# Altium schematic sheet ADC.SchDoc(ADC)
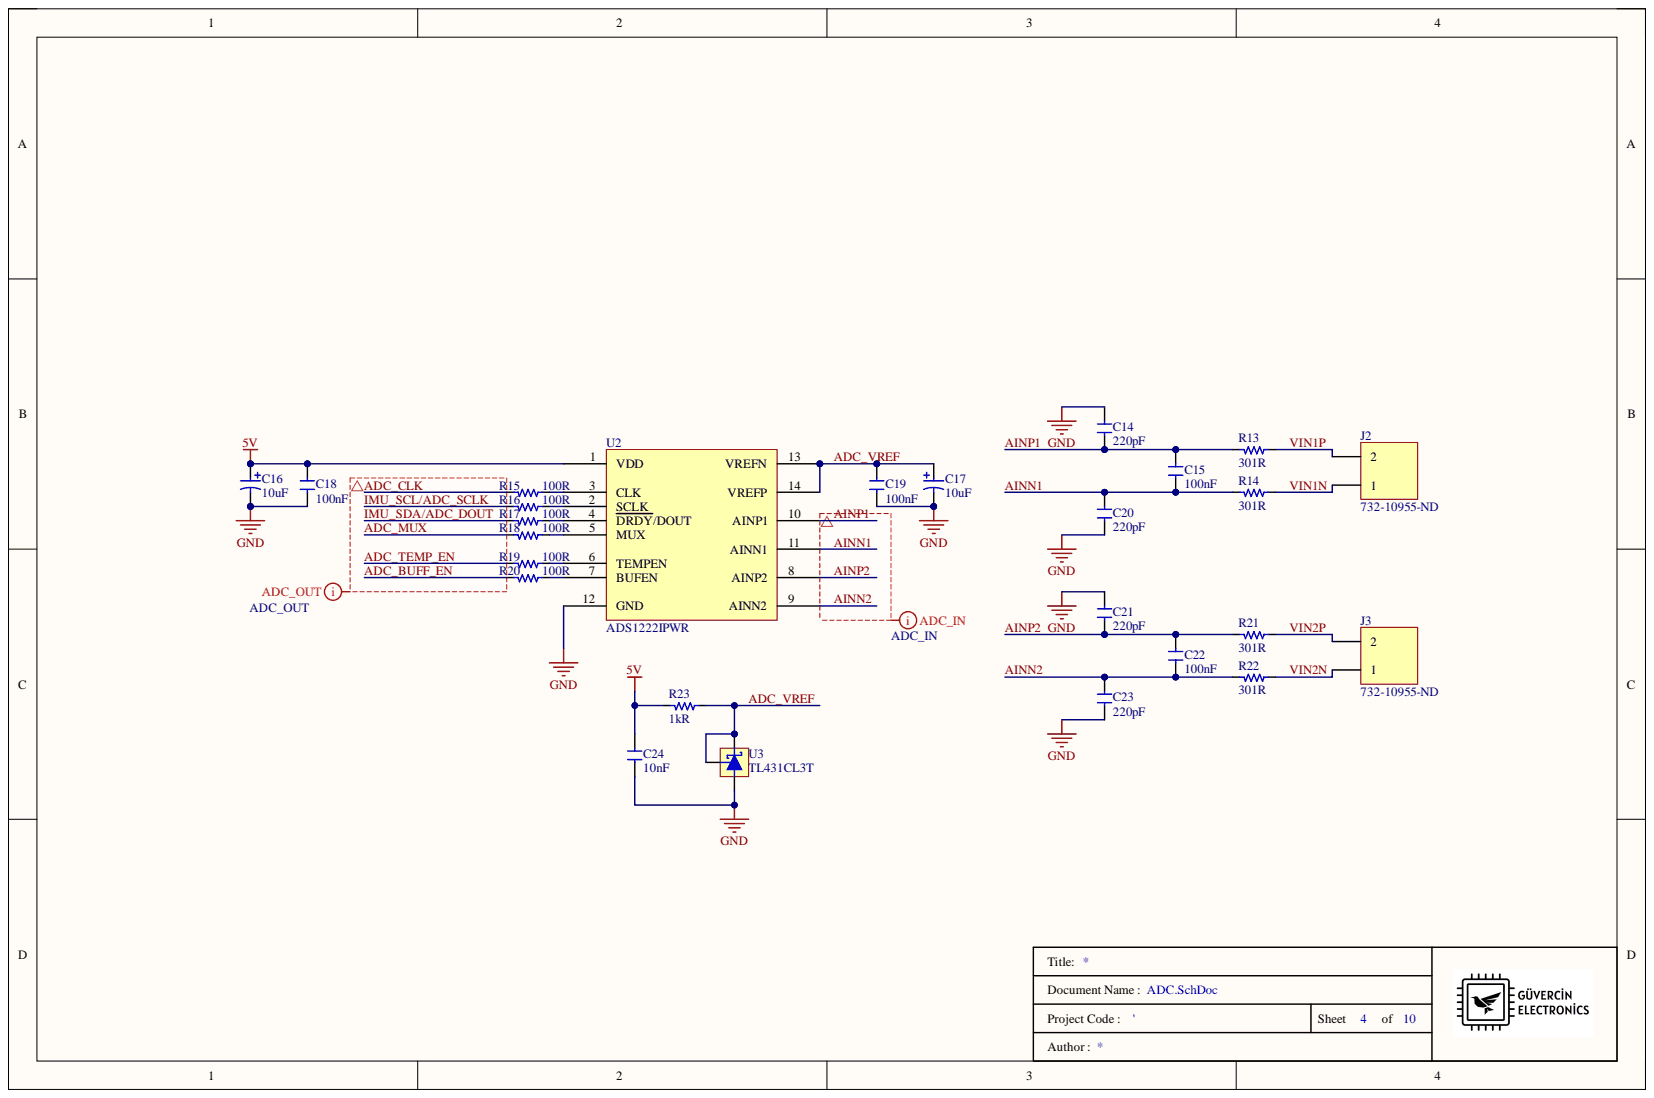
[diagram p0: ADC.SchDoc]

## Parts
C14  Capacitance: 220pF  [0805_CAP]  (hidden comment: CAP_220pF_50V_0805_C0G/NP0_CER)
C15  Capacitance: 100nF  [0805_CAP]  (hidden comment: CAP_0.1uF_50V_0805_X7R_CER)
C16  Capacitance: 10uF  [1206_TANT_CAP]  (hidden comment: CAP_10uF_10V_10%_1206_TANT)
C17  Capacitance: 10uF  [1206_TANT_CAP]  (hidden comment: CAP_10uF_10V_10%_1206_TANT)
C18  Capacitance: 100nF  [0805_CAP]  (hidden comment: CAP_0.1uF_50V_0805_X7R_CER)
C19  Capacitance: 100nF  [0805_CAP]  (hidden comment: CAP_0.1uF_50V_0805_X7R_CER)
C20  Capacitance: 220pF  [0805_CAP]  (hidden comment: CAP_220pF_50V_0805_C0G/NP0_CER)
C21  Capacitance: 220pF  [0805_CAP]  (hidden comment: CAP_220pF_50V_0805_C0G/NP0_CER)
C22  Capacitance: 100nF  [0805_CAP]  (hidden comment: CAP_0.1uF_50V_0805_X7R_CER)
C23  Capacitance: 220pF  [0805_CAP]  (hidden comment: CAP_220pF_50V_0805_C0G/NP0_CER)
C24  Capacitance: 10nF  [0805_CAP]  (hidden comment: CAP_10nF_%10_50V_0805_X7R_CER)
J2  Supplier Part Number 1: 732-10955-ND  [1x2_TERMINAL BLOCK]  (hidden comment: CONN_1X2_Terminal Block)
J3  Supplier Part Number 1: 732-10955-ND  [1x2_TERMINAL BLOCK]  (hidden comment: CONN_1X2_Terminal Block)
R13  Resistance: 301R  [0805_RES]  (hidden comment: RES_301 OHM_1%_0805_1/8W)
R14  Resistance: 301R  [0805_RES]  (hidden comment: RES_301 OHM_1%_0805_1/8W)
R15  Resistance: 100R  [0805_RES]  (hidden comment: RES_100 OHM_1%_0805_1/8W)
R16  Resistance: 100R  [0805_RES]  (hidden comment: RES_100 OHM_1%_0805_1/8W)
R17  Resistance: 100R  [0805_RES]  (hidden comment: RES_100 OHM_1%_0805_1/8W)
R18  Resistance: 100R  [0805_RES]  (hidden comment: RES_100 OHM_1%_0805_1/8W)
R19  Resistance: 100R  [0805_RES]  (hidden comment: RES_100 OHM_1%_0805_1/8W)
R20  Resistance: 100R  [0805_RES]  (hidden comment: RES_100 OHM_1%_0805_1/8W)
R21  Resistance: 301R  [0805_RES]  (hidden comment: RES_301 OHM_1%_0805_1/8W)
R22  Resistance: 301R  [0805_RES]  (hidden comment: RES_301 OHM_1%_0805_1/8W)
R23  Resistance: 1kR  [0805_RES]  (hidden comment: RES_1K OHM_1%_0805_1/8W)
U2  ADS1222IPWR  [TSOP65P640X120-14N]
U3  Manufacturer Part Number: TL431CL3T  [SOT95P237X112-3N]  (hidden comment: IC_VREF_SHUNT_TL431CL3T)

## Nets
5V: C16.1 C18.2 C24.2 R23.1 U2.1(VDD)
GND: C14.2 C16.2 C17.2 C18.1 C19.1 C20.1 C21.2 C23.1 C24.1 U2.12(GND) U3.3
ADC_BUFF_EN: R20.1
ADC_CLK: R15.1
ADC_MUX: R18.1
ADC_TEMP_EN: R19.1
ADC_VREF: C17.1 C19.2 R23.2 U2.13(VREFN) U2.14(VREFP) U3.1 U3.2
AINN1: C15.1 C20.2 R14.1 U2.11(AINN1)
AINN2: C22.1 C23.2 R22.1 U2.9(AINN2)
AINP1: C14.1 C15.2 R13.1 U2.10(AINP1)
AINP2: C21.1 C22.2 R21.1 U2.8(AINP2)
IMU_SCL/ADC_SCLK: R16.1
IMU_SDA/ADC_DOUT: R17.1
VIN1N: J2.1 R14.2
VIN1P: J2.2 R13.2
VIN2N: J3.1 R22.2
VIN2P: J3.2 R21.2
NetR15_2: R15.2 U2.3(CLK)
NetR16_2: R16.2 U2.2(SCLK)
NetR17_2: R17.2 U2.4(DRDY/DOUT)
NetR18_2: R18.2 U2.5(MUX)
NetR19_2: R19.2 U2.6(TEMPEN)
NetR20_2: R20.2 U2.7(BUFEN)

## Library data of the parts
C14 C20 C21 C23 = CAP_220pF_50V_0805_C0G/NP0_CER: CAP CER 220PF 50V C0G/NP0 0805; Manufacturer: Samsung; Manufacturer Part Number: CL21C221JBANNNC; Dielectric: C0G; Material: Ceramic; Temperature Coefficient: 300ppm/°C; Tolerance: 5%; Case Code (Metric): 2012; Case Code (Imperial): 0805; Case/Package: 0805; Category: Ceramic Capacitors; Component Kind: Standard; ComponentLink1Description: https://datasheet.ciiva.com/25788/cl21c221jbannnc-25788370.pdf?src-supplier=Digi-Key; ComponentLink1URL: https://datasheet.ciiva.com/25788/cl21c221jbannnc-25788370.pdf?src-supplier=Digi-Key; ComponentLink2Description: https://datasheet.ciiva.com/29199/453859-da-01-en-vs-kondensator-0805-29199965.pdf?src-supplier=Conrad; ComponentLink2URL: https://datasheet.ciiva.com/29199/453859-da-01-en-vs-kondensator-0805-29199965.pdf?src-supplier=Conrad; ComponentLink3Description: https://datasheet.ciiva.com/16428/cl05b104kq5nnnc-16428917.pdf?src-supplier=Future+Electronics; ComponentLink3URL: https://datasheet.ciiva.com/16428/cl05b104kq5nnnc-16428917.pdf?src-supplier=Future+Electronics; Construction: Flat; Depth: 1.25mm; Height: 0.65mm; Lead Free: Lead Free; Length: 2mm; Library Name: Main Component Library.IntLib; Max Operating Temperature: 125°C; Min Operating Temperature: -55°C; Mount: Surface Mount; Package Quantity: 1; Packaging: Tape and Reel; Pricing 1: 1=0,10000, 10=0,05200, 50=0,02840, 100=0,02320, 500=0,01660, 1000=0,01304 (USD); Radiation Hardening: No; RoHS: Yes; RoHS Compliant: Yes; Series: CL; Stock 1: 130340; Supplier 1: Digi-Key; Supplier Part Number 1: 1276-1164-1-ND; Thickness: 0.03inch; Voltage: 50V; Voltage Rating: 50V; Voltage Rating (DC): 50V; Width: 0.049inch
C15 C18 C19 C22 = CAP_0.1uF_50V_0805_X7R_CER: Cap Ceramic 0.1uF 50V X7R 20% SMD 0805 125C Paper T/R; Manufacturer: KEMET; Manufacturer Part Number: C0805C104M5RACTU; Case/Package: 0805; Category: Ceramic Capacitors; Component Kind: Standard; ComponentLink1Description: http://uk.rs-online.com/webdocs/13da/0900766b813daa06.pdf?src-supplier=RSComponents; ComponentLink1URL: http://uk.rs-online.com/webdocs/13da/0900766b813daa06.pdf?src-supplier=RSComponents; ComponentLink2Description: https://www.verical.com/server-webapp/html/getDatasheet?partID=774625&src-supplier=Verical; ComponentLink2URL: https://www.verical.com/server-webapp/html/getDatasheet?partID=774625&src-supplier=Verical; ComponentLink3Description: http://www.farnell.com/datasheets/2739857.pdf?src-supplier=Farnell; ComponentLink3URL: http://www.farnell.com/datasheets/2739857.pdf?src-supplier=Farnell; ComponentLink4Description: http://uk.rs-online.com/webdocs/1515/0900766b81515223.pdf?src-supplier=RSComponents; ComponentLink4URL: http://uk.rs-online.com/webdocs/1515/0900766b81515223.pdf?src-supplier=RSComponents; ComponentLink5Description: http://components.arrow.com/part/dataview/0323_00324812?src-supplier=Arrow+Europe; ComponentLink5URL: http://components.arrow.com/part/dataview/0323_00324812?src-supplier=Arrow+Europe; ComponentLink6Description: http://uk.rs-online.com/webdocs/1528/0900766b81528e2f.pdf?src-supplier=RSComponents; ComponentLink6URL: http://uk.rs-online.com/webdocs/1528/0900766b81528e2f.pdf?src-supplier=RSComponents; ComponentLink7Description: http://www.farnell.com/datasheets/2739857.pdf?src-supplier=Newark; ComponentLink7URL: http://www.farnell.com/datasheets/2739857.pdf?src-supplier=Newark; ComponentLink8Description: http://www.alliedelec.com/m/d/9bfe7773041ffe9d406e68bc7b377fdd.pdf?src-supplier=Allied+Electronics; ComponentLink8URL: http://www.alliedelec.com/m/d/9bfe7773041ffe9d406e68bc7b377fdd.pdf?src-supplier=Allied+Electronics; ComponentLink9Description: https://api.kemet.com/component-edge/download/datasheet/C0805C104M5RACTU.pdf?src-supplier=Digi-Key; ComponentLink9URL: https://api.kemet.com/component-edge/download/datasheet/C0805C104M5RACTU.pdf?src-supplier=Digi-Key; ComponentLink10Description: http://capacitoredge.kemet.com/capedge2/DataSheet?pn=C0805C104M5RACTU&src-supplier=Avnet+Europe; ComponentLink10URL: http://capacitoredge.kemet.com/capedge2/DataSheet?pn=C0805C104M5RACTU&src-supplier=Avnet+Europe; ComponentLink11Description: http://www.farnell.com/datasheets/2739857.pdf?src-supplier=Element14; ComponentLink11URL: http://www.farnell.com/datasheets/2739857.pdf?src-supplier=Element14; ComponentLink12Description: http://www.mouser.com/ds/2/212/KEM_C1002_X7R_SMD-1102033.pdf?src-supplier=Mouser; ComponentLink12URL: http://www.mouser.com/ds/2/212/KEM_C1002_X7R_SMD-1102033.pdf?src-supplier=Mouser; ComponentLink13Description: https://www.verical.com/server-webapp/html/getDatasheet?partID=91523&src-supplier=Verical; ComponentLink13URL: https://www.verical.com/server-webapp/html/getDatasheet?partID=91523&src-supplier=Verical; ComponentLink14Description: http://components.arrow.com/part/dataview/2091108S8109171N7429?src-supplier=Arrow+Electronics; ComponentLink14URL: http://components.arrow.com/part/dataview/2091108S8109171N7429?src-supplier=Arrow+Electronics; Depth: 1.25mm; Dielectric: X7R; Dielectric Material: Ceramic; Height: 0.9mm; Length: 2mm; Library Name: Main Component Library.IntLib; Max Operating Temperature: 125°C; Min Operating Temperature: -55°C; Mount: Surface Mount; Packaging: Tape and Reel; Pricing 1: 1=0,10000, 10=0,06400, 50=0,03500, 100=0,02860, 500=0,02040, 1000=0,01603 (USD); Radiation Hardening: No; RoHS: Yes; Stock 1: 4863; Supplier 1: Digi-Key; Supplier Part Number 1: 399-C0805C104M5RAC7800CT-ND; Tolerance: 20%; Voltage Rating (DC): 50V
C16 C17 = CAP_10uF_10V_10%_1206_TANT: Cap Tant Solid 10uF 10V A CASE 10% (3.2 X 1.6 X 1.6mm) Inward L SMD 3216-18 3 Ohm 125C T/R; Manufacturer: Kyocera AVX; Manufacturer Part Number: TAJA106K010SNJ; Dissipation Factor: 6%; ESR (Equivalent Series Resistance): 3R; Leakage Current: 1uA; Polarity: Polar; Case Code (Metric): 3216; Case Code (Imperial): 1206; Case/Package: Molded; Category: Tantalum Capacitors; Component Kind: Standard; ComponentLink1Description: https://datasheet.ciiva.com/12909/taj-27497-12909898.pdf?src-supplier=Mouser; ComponentLink1URL: https://datasheet.ciiva.com/12909/taj-27497-12909898.pdf?src-supplier=Mouser; Construction: Flat; Depth: 1.6mm; Height: 1.8mm; Lead Free: Lead Free; Length: 3.2mm; Library Name: Main Component Library.IntLib; Max Operating Temperature: 125°C; Min Operating Temperature: -55°C; Number of Pins: 2; Package Quantity: 8000; Packaging: Tape and Reel; Pricing 1: 1=0,31000, 10=0,21300, 50=0,14920, 100=0,12780, 500=0,09584, 1000=0,08094, 2500=0,07668 (USD); Radiation Hardening: No; RoHS: Yes; RoHS Compliant: Yes; Series: TAJ; Stock 1: 14579; Supplier 1: Digi-Key; Supplier Part Number 1: 478-13122-1-ND; Termination: SMD/SMT; Tolerance: 10%; Voltage Rating: 10V; Voltage Rating (DC): 10V; Width: 1.6mm
C24 = CAP_10nF_%10_50V_0805_X7R_CER: CAP CER 10000PF 50V X7R 0805; Manufacturer: Samsung; Manufacturer Part Number: CL21B103KBANNNC; Material: Ceramic; Tolerance: 10%; Case Code (Metric): 2012; Case Code (Imperial): 0805; Case/Package: 0805; Category: Ceramic Capacitors; Component Kind: Standard; ComponentLink1Description: https://datasheet.ciiva.com/25709/cl21b103kbannnc-25709781.pdf?src-supplier=Digi-Key; ComponentLink1URL: https://datasheet.ciiva.com/25709/cl21b103kbannnc-25709781.pdf?src-supplier=Digi-Key; ComponentLink2Description: https://datasheet.ciiva.com/29199/455082-da-01-en-vs-kondensator-0805-29199459.pdf?src-supplier=Conrad; ComponentLink2URL: https://datasheet.ciiva.com/29199/455082-da-01-en-vs-kondensator-0805-29199459.pdf?src-supplier=Conrad; Construction: Flat; Contact Plating: Tin; Depth: 1.25mm; Dielectric: X7R; Height: 0.65mm; Lead Free: Lead Free; Length: 2mm; Library Name: Main Schematic Library.SchLib; Max Operating Temperature: 125°C; Min Operating Temperature: -55°C; Mount: Surface Mount; Packaging: Tape and Reel; Pricing 1: 1=0,10000, 10=0,04600, 50=0,02500, 100=0,02050, 500=0,01464, 1000=0,01150 (USD); Radiation Hardening: No; RoHS: Yes; RoHS Compliant: Yes; Series: CL; Stock 1: 1431273; Supplier 1: Digi-Key; Supplier Part Number 1: 1276-1015-1-ND; Thickness: 0.03inch; Voltage: 50V; Voltage Rating: 50V; Voltage Rating (DC): 50V; Width: 0.049inch
J2 J3 = CONN_1X2_Terminal Block: Series 1377 - 5.00 mm Horizontal Entry with Pressure Clamp WR-TBL, 2 pin; Manufacturer: Wurth Electronics; Manufacturer Part Number: 691137710002; Current: 16A; Number of Levels: 1; Number of Positions Per Level: 2; Plating: Copper Alloy; Voltage: 300V; Wire Gauge (Max): 30AWG; Wire Gauge (Min): 12AWG; Category: Terminal Blocks; Color: Green; Component Kind: Standard; ComponentLink1Description: https://katalog.we-online.de/em/datasheet/691137710002.pdf?src-supplier=Digi-Key; ComponentLink1URL: https://katalog.we-online.de/em/datasheet/691137710002.pdf?src-supplier=Digi-Key; Housing Material: PC; Library Name: Main Schematic Library.SchLib; Max Operating Temperature: 120°C; Min Operating Temperature: -30°C; Mount: Through Hole; Packaging: Bulk; Pricing 1: 1=0,39000, 10=0,35200, 50=0,31100, 100=0,27900, 500=0,26900 (USD); RoHS: No; Stock 1: 10587; Supplier 1: Digi-Key; Termination: Screw
R13 R14 R21 R22 = RES_301 OHM_1%_0805_1/8W: Res Thick Film 0805 301 Ohm 1% 1/8W ±100ppm/°C Molded SMD SMD Paper T/R; Manufacturer: Yageo; Manufacturer Part Number: RC0805FR-10301RL; Case/Package: 0805; Category: Chip SMD Resistors; Component Kind: Standard; ComponentLink1Description: http://www.yageo.com/documents/recent/PYu-RC_Group_51_RoHS_L_2.pdf?src-supplier=Avnet; ComponentLink1URL: http://www.yageo.com/documents/recent/PYu-RC_Group_51_RoHS_L_2.pdf?src-supplier=Avnet; Contact Plating: Tin,Matte; Library Name: Main Schematic Library.SchLib; Max Operating Temperature: 155°C; Min Operating Temperature: -55°C; Pricing 1: 1=0,10000, 10=0,04200, 100=0,01690, 1000=0,00759, 2500=0,00659, 5000=0,00544 (USD); Radiation Hardening: No; Resistor Type: Thick Film; RoHS: Yes; RoHS Compliant: Yes; Stock 1: 17300; Supplier 1: Digi-Key; Supplier Part Number 1: 13-RC0805FR-10301RLCT-ND; Temperature Coefficient: 100ppm/°C; Tolerance: 1%
R15-R20 = RES_100 OHM_1%_0805_1/8W: 贴片电阻 100Ω ± 1.00% 0.125W ± 100ppm/℃ 0805; Manufacturer: Yageo; Manufacturer Part Number: RC0805FR-07100RL; Application(s): HIGH RELIABILITY; Max Power Dissipation: 125mW; Temperature Coefficient: 100ppm/°C; Tolerance: 1%; Voltage Rating: 150V; Case Code (Metric): 2012; Case Code (Imperial): 0805; Case/Package: 0805; Category: Chip SMD Resistors; Component Kind: Standard; ComponentLink1Description: https://datasheet.ciiva.com/11808/0900766b8106beab-11808877.pdf?src-supplier=RSComponents; ComponentLink1URL: https://datasheet.ciiva.com/11808/0900766b8106beab-11808877.pdf?src-supplier=RSComponents; ComponentLink2Description: https://datasheet.ciiva.com/7941/1697719-7941680.pdf?src-supplier=Element14; ComponentLink2URL: https://datasheet.ciiva.com/7941/1697719-7941680.pdf?src-supplier=Element14; ComponentLink3Description: https://datasheet.ciiva.com/7660/38627-7660797.pdf?src-supplier=Element14; ComponentLink3URL: https://datasheet.ciiva.com/7660/38627-7660797.pdf?src-supplier=Element14; ComponentLink4Description: https://datasheet.ciiva.com/2456/pyu-r-int-thick-7-2456987.pdf?src-supplier=Digi-Key; ComponentLink4URL: https://datasheet.ciiva.com/2456/pyu-r-int-thick-7-2456987.pdf?src-supplier=Digi-Key; Depth: 1.25mm; Features: Moisture Resistant; Height: 0.5mm; Lead Free: Lead Free; Lead Pitch: 0.4mm; Length: 2mm; Library Name: Main Component Library.IntLib; Max Operating Temperature: 155°C; Military Standard: Not; Min Operating Temperature: -55°C; Number of Pins: 2; Number of Terminations: 2; Package Quantity: 5000; Packaging: Cut Tape; Power Rating: 125mW; Pricing 1: 1=0,10000, 10=0,04200, 100=0,01690, 1000=0,00759, 2500=0,00659 (USD); REACH SVHC: Unknown; Resistor Type: Thick Film; RoHS: Yes; RoHS Compliant: Yes; Series: RC; Stock 1: 3418646; Supplier 1: Digi-Key; Supplier Part Number 1: 311-100CRCT-ND; Technology: THICK FILM; Termination: SMD/SMT; Type: General Purpose; Voltage Rating (DC): 150V; Weight: 0.000917oz; Width: 1.25mm
R23 = RES_1K OHM_1%_0805_1/8W: 贴片电阻 1000Ω ± 1.00% 0.125W ± 100ppm/℃ 0805; Manufacturer: Yageo; Manufacturer Part Number: RC0805FR-071KL; Max Power Dissipation: 125mW; Resistance Tolerance: 1%; Temperature Coefficient: 100ppm/°C; Voltage Rating: 150V; Case Code (Metric): 2012; Case Code (Imperial): 0805; Case/Package: 0805; Category: Chip SMD Resistors; Component Kind: Standard; Construction: Rectangular; Depth: 1.25mm; Features: Moisture Resistant; Height: 0.024inch; Lead Free: Lead Free; Length: 0.079inch; Library Name: Main Component Library.IntLib; Max Operating Temperature: 155°C; Military Standard: Not; Min Operating Temperature: -55°C; Number of Pins: 2; Number of Terminations: 2; Package Quantity: 1; Packaging: Tape and Reel; Power Rating: 125mW; Pricing 1: 1=0,10000, 10=0,04200, 100=0,01690, 1000=0,00759, 2500=0,00659 (USD); REACH SVHC: Unknown; Resistor Type: Thick Film; RoHS: Yes; RoHS Compliant: Yes; Series: RC; Size Code: 0805; Stock 1: 11155441; Supplier 1: Digi-Key; Supplier Part Number 1: 311-1.00KCRCT-ND; Termination: SMD/SMT; Tolerance: 1%; Voltage Rating (DC): 150V; Width: 0.049inch
U2 = IC_ADS1222IPWR: 24 Bit Analog to Digital Converter 2 Input 1 Sigma-Delta 14-TSSOP; Manufacturer: Texas Instruments; Conversion Rate: 0.24KS/s; Differential Nonlinearity: 1LSB; Max Power Dissipation: 2.25mW; Max Supply Voltage: 5.5V; Min Supply Voltage: 2.7V; Nominal Supply Current: 425uA; Number of A/D Converters: 1; Number of Analog Inputs: 2; Number of Bits: 24; Operating Supply Voltage: 5V; Polarity: Bipolar; Power Consumption: 1.35mW; Power Dissipation: 1.5mW; Resolution: 24b; Sampling Rate: 240S/s; Supply Type: Analog,Digital; Input Type: DIFFERENTIAL; Interface: SPI; Case/Package: TSSOP; Category: Analog to Digital Converters (ADCs); Component Kind: Standard; ComponentLink1Description: https://datasheet.ciiva.com/4777/sbas314b-94224-4777160.pdf?src-supplier=Mouser; ComponentLink1URL: https://datasheet.ciiva.com/4777/sbas314b-94224-4777160.pdf?src-supplier=Mouser; ComponentLink2Description: https://datasheet.ciiva.com/14260/ads1222-14260209.pdf?src-supplier=Avnet; ComponentLink2URL: https://datasheet.ciiva.com/14260/ads1222-14260209.pdf?src-supplier=Avnet; ComponentLink3Description: https://datasheet.ciiva.com/1304/ads1222-1304984.pdf?src-supplier=Digi-Key; ComponentLink3URL: https://datasheet.ciiva.com/1304/ads1222-1304984.pdf?src-supplier=Digi-Key; Contact Plating: Gold; Lead Free: Lead Free; Library Name: Main Component Library.IntLib; Manufacturer Part Number: ADS1222IPWR; Max Operating Temperature: 85°C; Max Supply Voltage (DC): 5.5V; Min Operating Temperature: -40°C; Min Supply Voltage (DC): 2.7V; Number of Channels: 2; Number of Converters: 1; Number of Elements: 1; Number of Pins: 14; Number of Inputs: 2; Package Quantity: 2000; Packaging: Tape and Reel; Pricing 1: 1=10,41000, 10=9,40100, 25=8,96360, 100=7,78300, 250=7,43324, 500=7,21876 (USD); RoHS: Yes; RoHS Compliant: Yes; Stock 1: 1473; Supplier 1: Digi-Key; Supplier Part Number 1: 296-48476-1-ND
U3 = IC_VREF_SHUNT_TL431CL3T: V-Ref Adjustable 2.495V to 36V 100mA 3-Pin SOT-23 T/R; Manufacturer: STMicroelectronics; Cathode Current: 1mA; Max Input Voltage: 37V; Number of Channels: 1; Reference Type: Shunt,Adjustable; Tolerance: 2.21%; Case/Package: SOT-23-3; Category: Voltage References; Component Kind: Standard; ComponentLink1Description: https://datasheet.ciiva.com/41424/001184463-da-01-en-ic-vref-shunt-pre-tl431cl3t-sot-23-3-stm-41424441.pdf?src-supplier=Conrad; ComponentLink1URL: https://datasheet.ciiva.com/41424/001184463-da-01-en-ic-vref-shunt-pre-tl431cl3t-sot-23-3-stm-41424441.pdf?src-supplier=Conrad; ComponentLink2Description: https://datasheet.ciiva.com/2006/cd00000986-2006196.pdf?src-supplier=Digi-Key; ComponentLink2URL: https://datasheet.ciiva.com/2006/cd00000986-2006196.pdf?src-supplier=Digi-Key; ComponentLink3Description: https://datasheet.ciiva.com/2006/cd00000986-2006197.pdf?src-supplier=Digi-Key; ComponentLink3URL: https://datasheet.ciiva.com/2006/cd00000986-2006197.pdf?src-supplier=Digi-Key; ComponentLink4Description: https://datasheet.ciiva.com/2006/cd00000986-2006198.pdf?src-supplier=Digi-Key; ComponentLink4URL: https://datasheet.ciiva.com/2006/cd00000986-2006198.pdf?src-supplier=Digi-Key; ComponentLink5Description: https://datasheet.ciiva.com/16501/cd00000986-16501308.pdf?src-supplier=Future+Electronics; ComponentLink5URL: https://datasheet.ciiva.com/16501/cd00000986-16501308.pdf?src-supplier=Future+Electronics; Lead Free: Lead Free; Library Name: Main Component Library.IntLib; Max Operating Temperature: 70°C; Max Output Current: 100mA; Max Output Voltage: 36V; Min Operating Temperature: 0°C; Min Output Voltage: 2.495V; Mount: Surface Mount; Number of Pins: 3; Output Current: 100mA; Output Type: Adjustable; Output Voltage: 36V; Package Quantity: 3000; Packaging: Tape and Reel; Pricing 1: 1=0,48000, 10=0,41200, 25=0,38440, 100=0,30750, 250=0,28552, 500=0,24160, 1000=0,18669 (USD); Radiation Hardening: No; Reference Voltage: 2.495V; RoHS: Yes; RoHS Compliant: Yes; Series: -; Stock 1: 5995; Supplier 1: Digi-Key; Supplier Part Number 1: 497-11297-1-ND
